# Altium schematic sheet Boost Converter.SchDoc
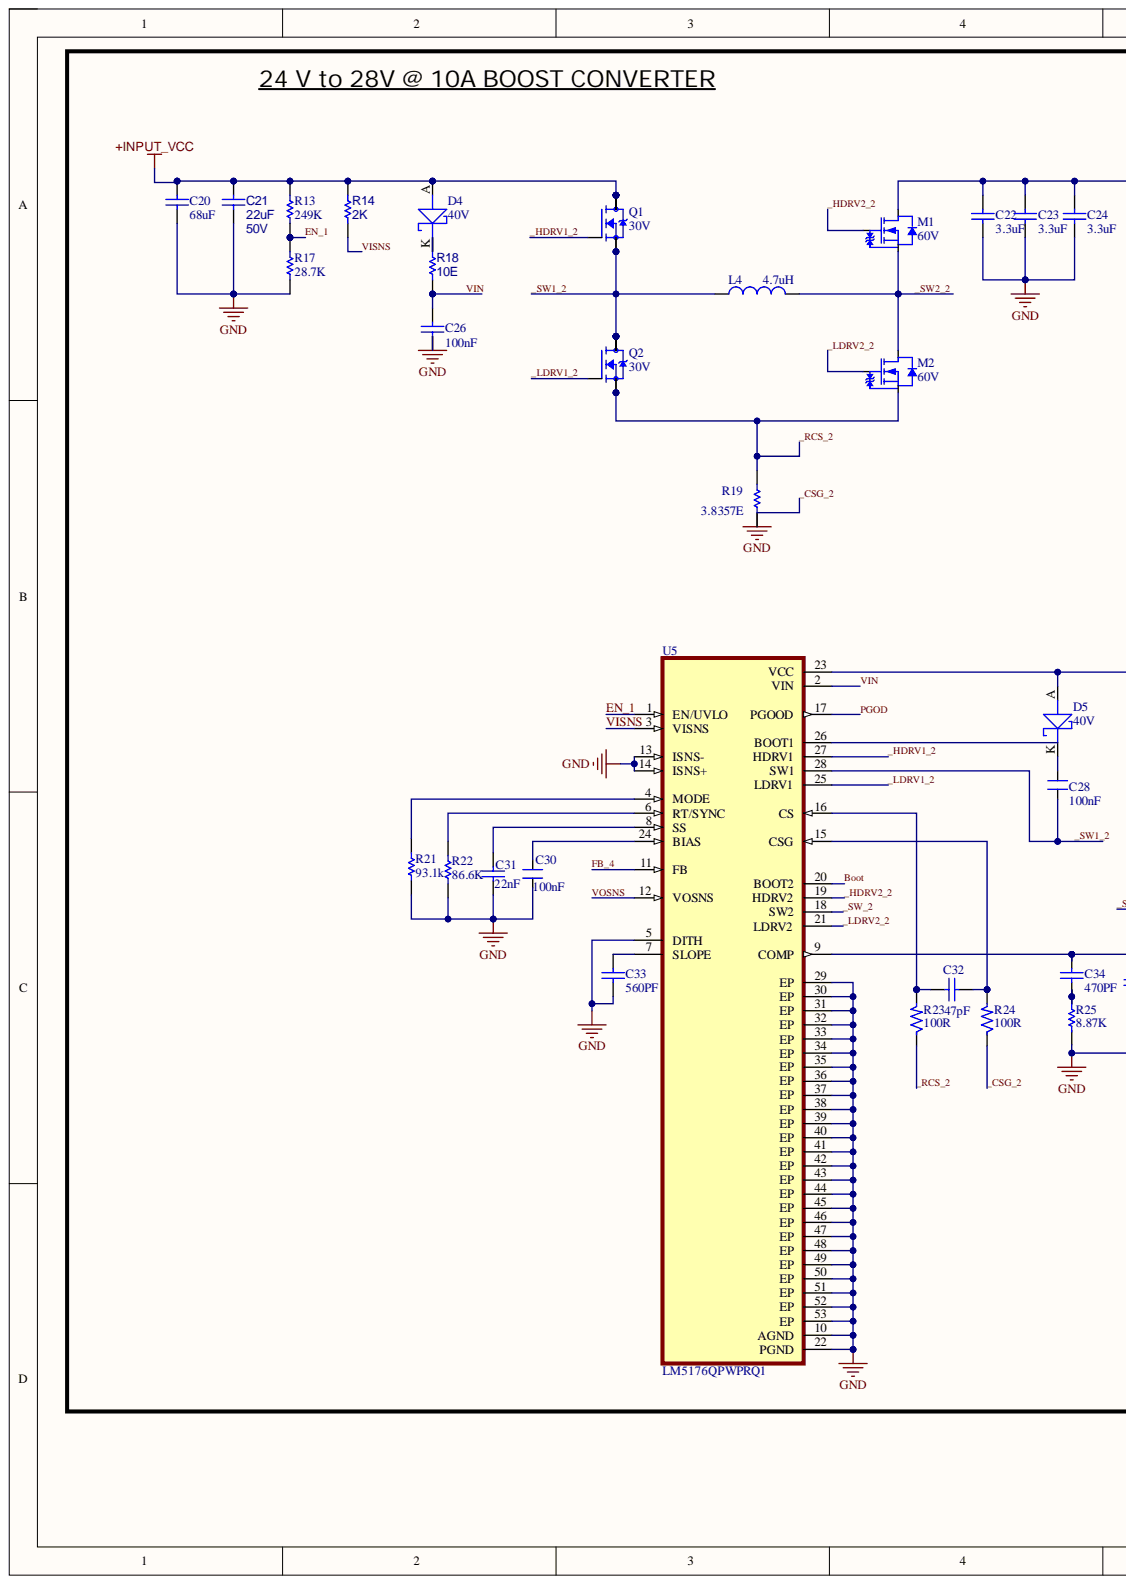
[diagram p0: Boost Converter.SchDoc, left]
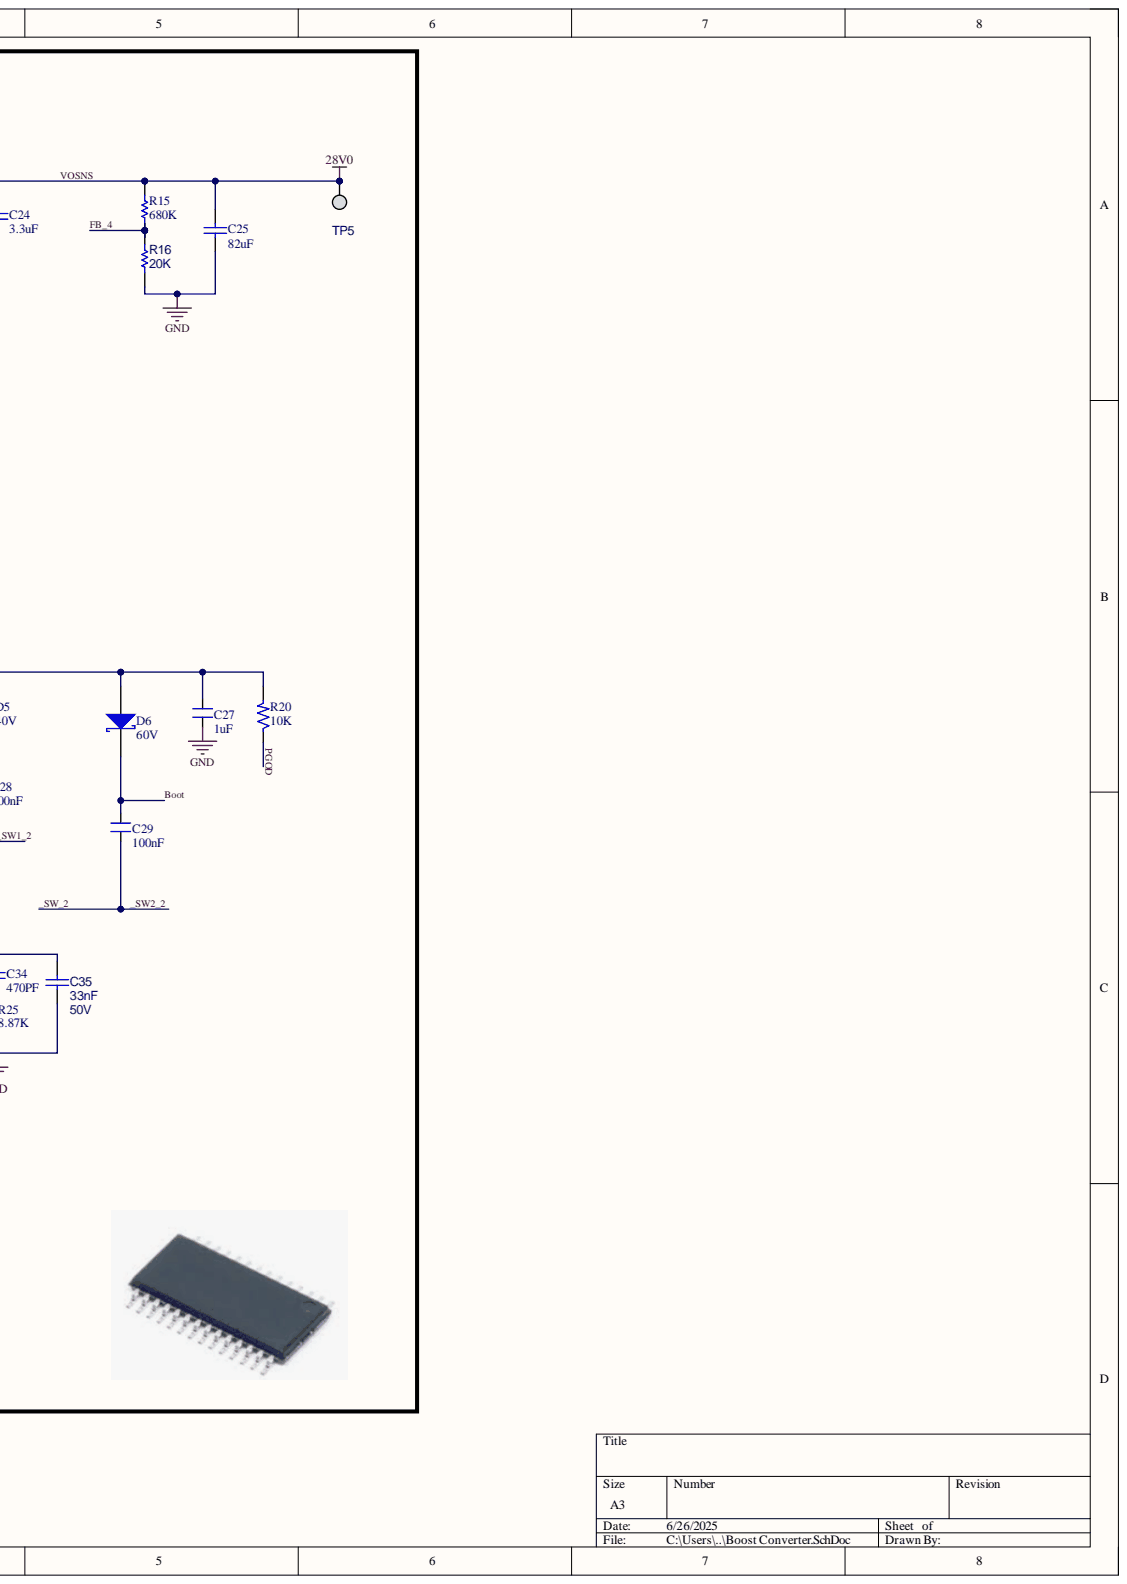
[diagram p1: Boost Converter.SchDoc, right]

## Parts
C20  68uF
C21  value 22uF  Voltage: 50V  [CAP-0805]  (hidden comment: 10uF)
C22  3.3uF  [CAP-1206]
C23  3.3uF  [CAP-1206]
C24  3.3uF  [CAP-1206]
C25  82uF
C26  100nF  [CAP-0603]
C27  1uF  [CAP_0603]
C28  100nF  [CAP_0805]
C29  100nF  [CAP_0805]
C30  100nF  [CAP_0805]
C31  22nF  [CAP-0603]
C32  47pF  [CAP-0603]
C33  560PF  [CAP-0603]
C34  470PF
C35  value 33nF  Voltage: 50V  [CAP-0805]  (hidden comment: 68pF)
D4  40V  [DIO_SMA_DO-214AC]
D5  40V  [DIO_SMA_DO-214AC]
D6  60V
L4  4.7uH
M1  60V
M2  60V
Q1  30V  [TRANS_CSD17571Q2]
Q2  30V  [TRANS_CSD17571Q2]
R13  249K
R14  2K  [RES-0603]
R15  680K  [RES-0603]
R16  20K  [RES-0603]
R17  28.7K  [RES-0402]
R18  10E  [RES-0603]
R19  3.8357E
R20  10K  [RES_0603]
R21  93.1k  [RES-0603]
R22  86.6K
R23  100R  [RES_0603]
R24  100R  [RES_0603]
R25  8.87K  [Model Name]
TP5  Test Point  [TestPoint]  (value not drawn)
U5  LM5176QPWPRQ1  [IC_LM5176QPWPRQ1]

## Nets
+INPUT_VCC: C20.2 C21.2 D4.A Q1.1 Q1.2 Q1.5 Q1.6 Q1.7 R13.2 R14.2
_CSG_2: C20.1 C21.1 C22.1 C23.1 C24.1 C25.1 C26.1 C27.1 C30.1 C31.1 C33.1 C35.1 R16.1 R17.2 R21.2 R22.1 R24.1 R25.1 U5.5(DITH) U5.10(AGND) U5.13(ISNS-) U5.14(ISNS+) U5.22(PGND) U5.29(EP) U5.30(EP) U5.31(EP) U5.32(EP) U5.33(EP) U5.34(EP) U5.35(EP) U5.36(EP) U5.37(EP) U5.38(EP) U5.39(EP) U5.40(EP) U5.41(EP) U5.42(EP) U5.43(EP) U5.44(EP) U5.45(EP) U5.46(EP) U5.47(EP) U5.48(EP) U5.49(EP) U5.50(EP) U5.51(EP) U5.52(EP) U5.53(EP)
_HDRV1_2: Q1.3 U5.27(HDRV1)
_HDRV2_2: M1.1 U5.19(HDRV2)
_LDRV1_2: Q2.3 U5.25(LDRV1)
_LDRV2_2: M2.1 U5.21(LDRV2)
_RCS_2: M2.2 Q2.4 Q2.8 R19.2 R23.1
_SW1_2: C28.1 L4.1 Q1.4 Q1.8 Q2.1 Q2.2 Q2.5 Q2.6 Q2.7 U5.28(SW1)
_SW2_2: C29.1 L4.2 M1.2 M2.3 U5.18(SW2)
Boot: C29.2 D6.2 U5.20(BOOT2)
EN_1: R13.2 R17.2 U5.1(EN/UVLO)
FB_4: R15.1 R16.2 U5.11(FB)
PGOD: R20.1 U5.17(PGOOD)
VIN: C26.2 R18.1 U5.2(VIN)
VISNS: R14.1 U5.3(VISNS)
VOSNS: C22.2 C23.2 C24.2 C25.2 M1.3 R15.2 TP5.1 U5.12(VOSNS)
NetC27_2: C27.2 D5.A D6.1 R20.2 U5.23(VCC)
NetC28_2: C28.2 D5.K
NetC30_2: C30.2 U5.24(BIAS)
NetC31_2: C31.2 U5.8(SS)
NetC32_1: C32.1 R23.2 U5.16(CS)
NetC32_2: C32.2 R24.2 U5.15(CSG)
NetC33_2: C33.2 U5.7(SLOPE)
NetC34_1: C34.1 R25.2
NetC34_2: C34.2 C35.2 U5.9(COMP)
NetD4_K: D4.K R18.2
NetR21_2: R21.2 U5.4(MODE)
NetR22_2: R22.2 U5.6(RT/SYNC)

Not connected: R19 1; U5 26(BOOT1)

## Library data of the parts
C20 = CAP_68uF_34.2857V: Component Kind: Standard; Description: CAP_68uF_34.2857V; Library Name: GENSET CONTROLLER BETA V01.SCHLIB
C21 = CAP: CAP_ 10UF _50V X5R _0805,C3225X7R1H106M250AC; Manufacturer: Murata Electronics; Part Number: GRM21BR61H106ME43L; Tolerance: ±1%; Component Kind: Standard; Package: 0805; Library Name: GENSET CONTROLLER BETA V01.SCHLIB
C22 = CAP_3.3uF_100V_1206: CAP_3.3uF_100V_1206_C3216X7S2A335M160AB; Component Kind: Standard; Library Name: GENSET CONTROLLER BETA V01.SCHLIB
C23 C24 = CAP_3.3uF_100V_1206: CAP_3.3uF_100V_1206,C3216X7S2A335M160AB; Component Kind: Standard; Library Name: GENSET CONTROLLER BETA V01.SCHLIB
C25 = CAP_22uF_35V,: CAP_22uF_35V, 35SVPF22M; Component Kind: Standard; Library Name: GENSET CONTROLLER BETA V01.SCHLIB
C26 = CAP_100nF_50V_0603: CAP_100nF_50V_0603,CGA3E2X7R1H104K080AA; Component Kind: Standard; Library Name: GENSET CONTROLLER BETA V01.SCHLIB
C27 = CAP_1uF-16V-10%-0603: 1µF ±10% 16V Ceramic Capacitor X7R 0603 (1608 Metric),EMK107B7105KA-T; Manufacturer: Yageo; Manufacturer Part Number: CC0603KRX7R7BB105; Case/Package: 0603; Component Kind: Standard; Supplier-1: Digikey; Library Name: EsteemPCB_COB_Course_intlib.IntLib
C28 C29 = CAP_100nF-25V-10%-0805: 0.1µF ±10% 25V Ceramic Capacitor X7R 0805 (2012 Metric),06033C104KAT2A; Manufacturer: Yageo; Manufacturer Part Number: CC0805KRX7R8BB104; Case/Package: 0805; Component Kind: Standard; Supplier-1: Digikey; Library Name: EsteemPCB_COB_Course_intlib.IntLib
C30 = CAP_100nF-25V-10%-0805: 0.1µF ±10% 50V Ceramic Capacitor X7R 0805 (2012 Metric),C0805C104M5RACTU; Manufacturer: Yageo; Manufacturer Part Number: CC0805KRX7R8BB104; Case/Package: 0805; Component Kind: Standard; Supplier-1: Digikey; Library Name: EsteemPCB_COB_Course_intlib.IntLib
C31 = CAP_15nF_25V_0603: CAP_15nF_25V_0603, C0603C153J3GACTU; Component Kind: Standard; Library Name: GENSET CONTROLLER BETA V01.SCHLIB
C32 = Cap_47pF_50V_0603: Cap_47pF_50V_0603,06035A470JAT2A; Component Kind: Standard; Library Name: GENSET CONTROLLER BETA V01.SCHLIB
C33 = CAP_220pF_50V_0603: CAP_220pF_50V_0603,CL10C221JB8NNNC; Component Kind: Standard; Library Name: GENSET CONTROLLER BETA V01.SCHLIB
C34 = CAP_6.8nF_16V_0201: C_6.8nF_16V_0201,CGA1A2X7R1C682K030BA; Component Kind: Standard; Library Name: GENSET CONTROLLER BETA V01.SCHLIB
C35 = CAP_18: CAP CER 68PF 50V C0G/NP0 0805,CC0805JRNP09BN680; Manufacturer: KEMET; Part Number: C0603C680J5GACAUTO; Component Kind: Standard; Package: 0603; Library Name: GENSET CONTROLLER BETA V01.SCHLIB
D4 D5 = DIO-S_550mV-40V-5A-SMA: Diode Schottky 40V 5A Surface Mount SMA,CDBK0540-HF; Alternate Part Number-1: SS34; Manufacturer: Multicomp; Manufacturer Part Number: SS34L RVG; Case/Package: SMA; Category: Diode; Component Kind: Standard; Number of Pins: 2; Supplier Part Number-1: SS34L RVG; Supplier-1: SIRICOM; Library Name: EsteemPCB_COB_Course_intlib.IntLib
D6 = Diode Sch _60V: Diode Sch _60V, B250A-13-F; Component Kind: Standard; Library Name: GENSET CONTROLLER BETA V01.SCHLIB
L4 = IND_2.2UH 22A 4.2 MOHM SMD: Component Kind: Standard; Description: IND_2.2UH 22A 4.2 MOHM SMD; Library Name: Miscellaneous Devices.SchLib
M1 M2 = Mosfet _N_60V_35A: Mosfet _N_60V_35A,CSD19502Q5B; Component Kind: Standard; Library Name: EsteemPCB_COB_Course_schlib.SchLib
Q1 Q2 = CSD17571Q2: 30-V, N channel NexFETâ„¢ power MOSFET, single SON 2 mm x 2 mm, 29 mOhm,CSD17571Q2; MF: Texas Instruments; Check_prices: https://www.snapeda.com/parts/CSD17571Q2/Texas+Instruments/view-part/?ref=eda; Component Kind: Standard; Library Name: CSD17571Q2.IntLib; MP: CSD17571Q2; Package: WSON-6 Texas Instruments; SnapEDA_Link: https://www.snapeda.com/parts/CSD17571Q2/Texas+Instruments/view-part/?ref=snap
R13 = RES_249K OHM 1% 1/20W 0201: RES 249K OHM 1% 1/20W 0201, RC0201FR-07249KL; Component Kind: Standard; Library Name: GENSET CONTROLLER BETA V1.01.SCHLIB
R14 = RES-2_32: RES SMD _2K OHM_ 1% 1/10W _0603,CRCW04022K00FKED; Manufacturer: YAGEO; Part Number: AC0603FR-072KL; Power Rating: 100mW; Component Kind: Standard; Package: 0603; Tolerance: ±1%; Value: 2K; Library Name: GENSET CONTROLLER BETA V01.SCHLIB
R15 = RES_680K_100mW_1%_0603: RES_680K_100mW_1%_0603,RC0603FR-07680KL; Component Kind: Standard; Library Name: GENSET CONTROLLER BETA V01.SCHLIB
R16 = RES-2_28: RES _20K OHM _1% _1/10W _0603,RC0603FR-0720KL; Manufacturer: YAGEO; Tolerance: ±1%; Case/Package: 0603; Component Kind: Standard; Part Number: RC0603FR-0720KL; Value: 20K; Library Name: GENSET CONTROLLER BETA V01.SCHLIB
R17 = RES_28.7K_50mW_1%_0201, RC0201FR-0728K7L: RES_28.7K_50mW_1%_0402, RC0201FR-0728K7L; Component Kind: Standard; Library Name: GENSET CONTROLLER BETA V01.SCHLIB
R18 = RES-2_24: RES 10 OHM 1% 1/10W 0603,RC0603FR-0710RL; Manufacturer: YAGEO; Part Number: AF0603FR-1310RL; Power Rating: 100mW; Case/Package: 0603; Component Kind: Standard; Tolerance: ±1%; Value: 10E; Library Name: GENSET CONTROLLER BETA V01.SCHLIB
R19 = RES_6m _3W_1%_4527: RES_6m _3W_1%_4527,WSR36L000FEA; Component Kind: Standard; Library Name: GENSET CONTROLLER BETA V01.SCHLIB
R20 = RES_10K-0.1W-1%-0603: 10 kOhms ±1% 0.1W, 1/10W Chip Resistor 0603 (1608 Metric) Thick Film,RC0603FR-0710KL; Manufacturer: Yageo; Case/Package: 0603; Component Kind: Standard; Manufacturer Part Number: RC0603FR-0710KL; Supplier-1: Digikey; Library Name: EsteemPCB_COB_Course_intlib.IntLib
R21 = Res_93.1k_100mW_1%_0603: Res_93.1k_100mW_1%_0603,RC0603FR-0793K1L; Component Kind: Standard; Library Name: GENSET CONTROLLER BETA V01.SCHLIB
R22 = Res_23.7k_50mW_1%_0201: Res_23.7k_50mW_1%_0201,RC0201FR-0723K7L; Component Kind: Standard; Library Name: GENSET CONTROLLER BETA V01.SCHLIB
R23 R24 = RES_100R-0.1W-1%-0603: 100 Ohms ±1% 0.1W, 1/10W Chip Resistor 0603 (1608 Metric) Thick Film,CRCW0603100RFKEA; Manufacturer: Yageo; Manufacturer Part Number: RC0603FR-07100RL; Case/Package: 0603; Component Kind: Standard; Supplier-1: Digikey; Library Name: EsteemPCB_COB_Course_intlib.IntLib
R25 = RES_18.7k_50mW_1%_0201: RES_18.7k_50mW_1%_0201,RC0201FR-0718K7L; Component Kind: Standard; Library Name: GENSET CONTROLLER BETA V01.SCHLIB
U5 = LM5176QPWPRQ1: 55-V wide VIN synchronous 4-switch buck-boost controller 28-HTSSOP -40 to 125; Availability: Unavailable; Component Kind: Standard; Library Name: LM5176QPWPRQ1.IntLib; MP: LM5176QPWPRQ1; Package: None; Price: None
TP5 = (no symbol name): Component Kind: Standard; Description: Test Point
